# Altium schematic sheet agent-mother.SchDoc
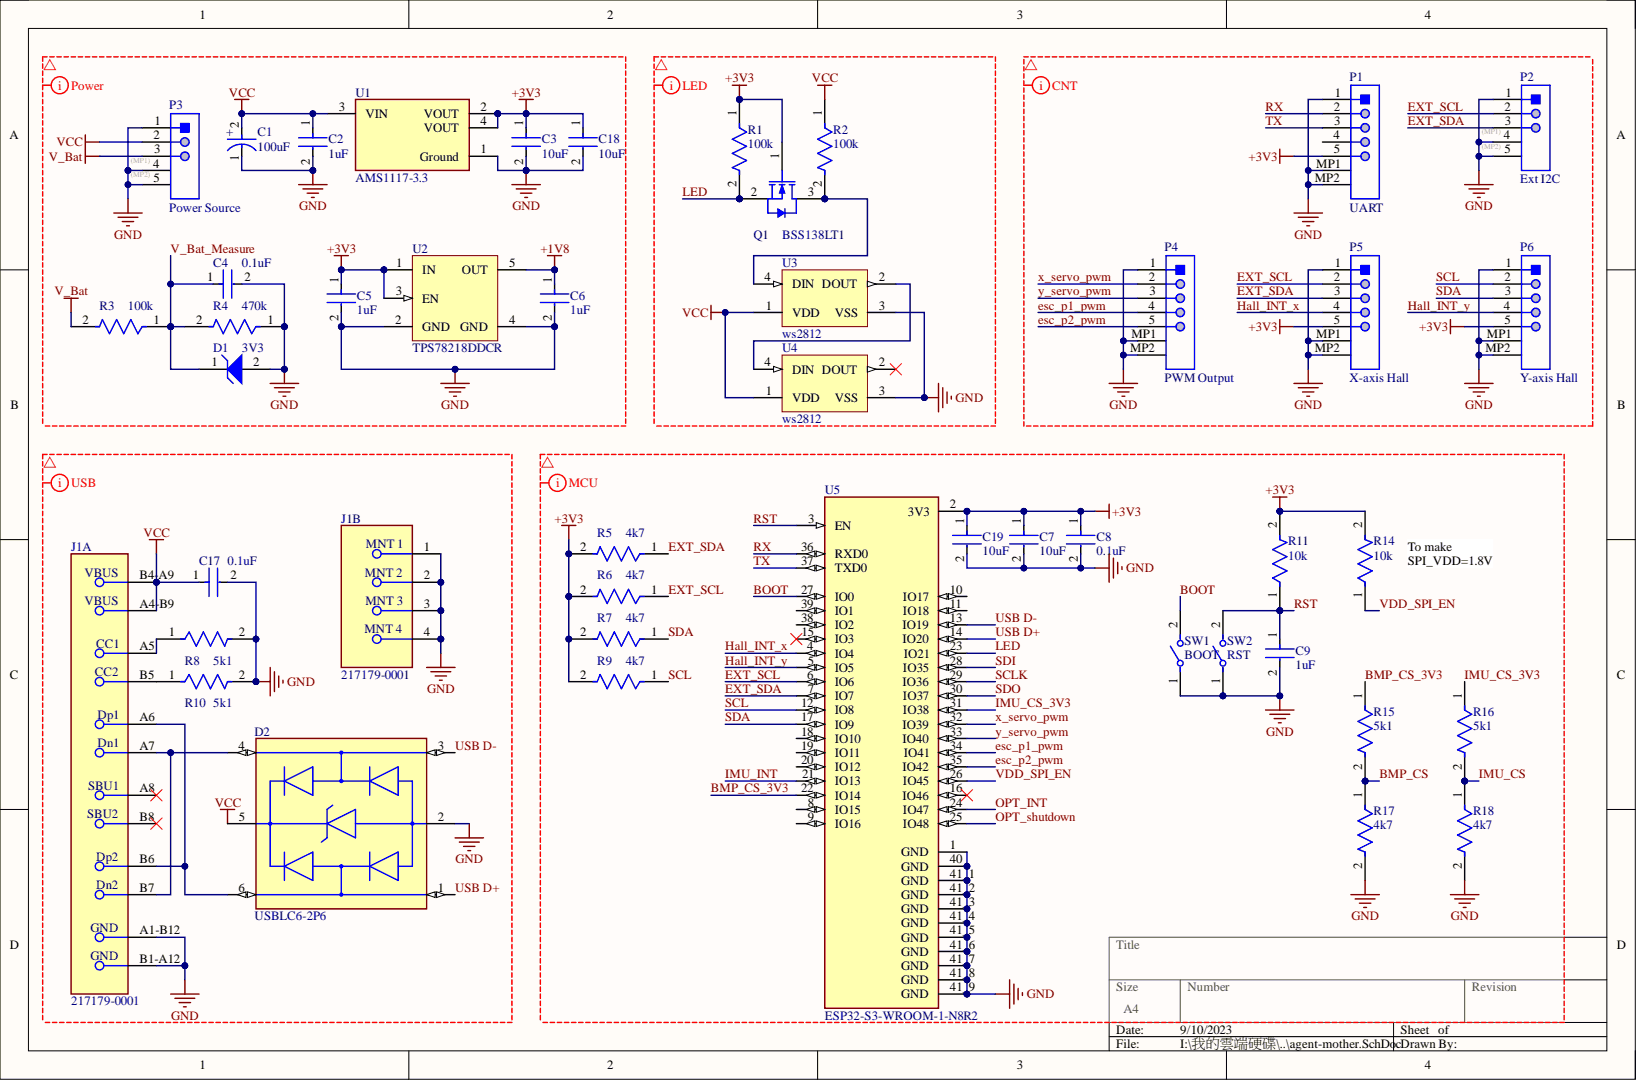
[diagram p0: agent-mother.SchDoc]

## Parts
C1  100uF  [FP-T495D107K016ATE125-MFG]
C2  1uF  [FP-C0402-BB-MFG]
C3  10uF  [FP-C0402-BB-MFG]
C4  0.1uF  [FP-C0402-BB-MFG]
C5  1uF  [FP-C0402-BB-MFG]
C6  1uF  [FP-C0402-BB-MFG]
C7  10uF  [FP-C0402-BB-MFG]
C8  0.1uF  [FP-C0402-BB-MFG]
C9  1uF  [FP-C0402-BB-MFG]
C17  0.1uF  [FP-C0402-BB-MFG]
C18  10uF  [FP-C0402-BB-MFG]
C19  10uF  [FP-C0402-BB-MFG]
D1  3V3  [ONSC-SOD-323-2-477-02_V]
D2  USBLC6-2P6  [SOT-23-6 USBLC6]
J1  217179-0001  [FP-217179-0001-MFG]  (parts A,B)
P1  UART  [MOLX-52361-0571-5_V]
P2  Ext I2C  [FP-53261-0371-MFG]
P3  Power Source  [FP-53261-0371-MFG]
P4  PWM Output  [MOLX-52361-0571-5_V]
P5  X-axis Hall  [MOLX-52361-0571-5_V]
P6  Y-axis Hall  [MOLX-52361-0571-5_V]
Q1  BSS138LT1  [ONSC-SOT-23-3-318-08_V]
R1  100k  [FP-CRCW0402-e3-IPC_A]
R2  100k  [FP-CRCW0402-e3-IPC_A]
R3  100k  [FP-CRCW0402-e3-IPC_A]
R4  470k  [FP-CRCW0402-e3-IPC_A]
R5  4k7  [FP-CRCW0402-e3-IPC_A]
R6  4k7  [FP-CRCW0402-e3-IPC_A]
R7  4k7  [FP-CRCW0402-e3-IPC_A]
R8  5k1  [FP-CRCW0402-e3-IPC_A]
R9  4k7  [FP-CRCW0402-e3-IPC_A]
R10  5k1  [FP-CRCW0402-e3-IPC_A]
R11  10k  [FP-CRCW0402-e3-IPC_A]
R14  10k  [FP-CRCW0402-e3-IPC_A]
R15  5k1  [FP-CRCW0402-e3-IPC_A]
R16  5k1  [FP-CRCW0402-e3-IPC_A]
R17  4k7  [FP-CRCW0402-e3-IPC_A]
R18  4k7  [FP-CRCW0402-e3-IPC_A]
SW1  BOOT  [FP-B3U-1000P-B-MFG]
SW2  RST  [FP-B3U-1000P-B-MFG]
U1  AMS1117-3.3  [FP-AMS1117-IPC_A]
U2  TPS78218DDCR  [SOT-23-OT5_M]
U3  ws2812  [ADA-RGB-1655_V]
U4  ws2812  [ADA-RGB-1655_V]
U5  ESP32-S3-WROOM-1-N8R2  [XCVR_ESP32S3WROOM1N8R8]

## Nets
+1V8: C6.1 U2.5(OUT)
+3V3: C3.1 C5.1 C7.1 C8.1 C18.1 C19.1 P1.5 P5.5 P6.5 Q1.1 R1.1 R5.2 R6.2 R7.2 R9.2 R11.2 R14.2 U1.2(VOUT) U1.4(VOUT) U2.1(IN) U2.3(EN) U5.2(3V3)
GND: C1.1 C2.2 C3.2 C4.2 C5.2 C6.2 C7.2 C8.2 C9.2 C17.2 C18.2 C19.2 D1.2 D2.2 J1.1 J1.2 J1.3 J1.4 J1.A1-B12 J1.B1-A12 P1.1 P1.MP1 P1.MP2 P2.1 P2.MP1 P2.MP2 P3.1 P3.MP1 P3.MP2 P4.1 P4.MP1 P4.MP2 P5.1 P5.MP1 P5.MP2 P6.1 P6.MP1 P6.MP2 R4.1 R8.2 R10.2 R17.2 R18.2 SW1.1 SW2.1 U1.1(Ground) U2.2(GND) U2.4(GND) U3.3(VSS) U4.3(VSS) U5.1(GND) U5.40(GND) U5.41_1(GND) U5.41_2(GND) U5.41_3(GND) U5.41_4(GND) U5.41_5(GND) U5.41_6(GND) U5.41_7(GND) U5.41_8(GND) U5.41_9(GND)
V_Bat: P3.3 R3.2
VCC: C1.2 C2.1 C17.1 D2.5 J1.A4-B9 J1.B4-A9 P3.2 R2.1 U1.3(VIN) U3.1(VDD) U4.1(VDD)
BMP_CS: R15.2 R17.1
BMP_CS_3V3: R15.1 U5.22(IO14)
BOOT: SW1.2 U5.27(IO0)
esc_p1_pwm: P4.4 U5.34(IO41)
esc_p2_pwm: P4.5 U5.35(IO42)
EXT_SCL: P2.2 P5.2 R6.1 U5.6(IO6)
EXT_SDA: P2.3 P5.3 R5.1 U5.7(IO7)
Hall_INT_x: P5.4 U5.4(IO4)
Hall_INT_y: P6.4 U5.5(IO5)
IMU_CS: R16.2 R18.1
IMU_CS_3V3: R16.1 U5.31(IO38)
IMU_INT: U5.21(IO13)
LED: Q1.2 R1.2 U5.23(IO21)
OPT_INT: U5.24(IO47)
OPT_shutdown: U5.25(IO48)
RST: C9.1 R11.1 SW2.2 U5.3(EN)
RX: P1.2 U5.36(RXD0)
SCL: P6.2 R9.1 U5.12(IO8)
SCLK: U5.29(IO36)
SDA: P6.3 R7.1 U5.17(IO9)
SDI: U5.28(IO35)
SDO: U5.30(IO37)
TX: P1.3 U5.37(TXD0)
USB D+: D2.1 U5.14(IO20)
USB D-: D2.3 U5.13(IO19)
V_Bat_Measure: C4.1 D1.1 R3.1 R4.2
VDD_SPI_EN: R14.1 U5.26(IO45)
x_servo_pwm: P4.2 U5.32(IO39)
y_servo_pwm: P4.3 U5.33(IO40)
NetD2_4: D2.4 J1.A7 J1.B7
NetD2_6: D2.6 J1.A6 J1.B6
NetJ1_A5: J1.A5 R8.1
NetJ1_B5: J1.B5 R10.1
NetQ1_3: Q1.3 R2.2 U3.4(DIN)
NetU3_2: U3.2(DOUT) U4.4(DIN)

Not connected: J1 A8 B8; P1 4; U4 2(DOUT); U5 8(IO15) 9(IO16) 10(IO17) 11(IO18) 15(IO3) 16(IO46) 18(IO10) 19(IO11) 20(IO12) 38(IO2) 39(IO1)

## Library data of the parts
C1 = CMP-2000-07207-2: CAP TANT 100UF 10% 16V 2917; Dielectric Material: Tantalum; Dissipation Factor: 8%; ESR (Equivalent Series Resistance): 125mR; Life (Hours): 2000Hour; Polarity: Polar; Capacitance: 100uF; Case Code (Imperial): 2917; Case Code (Metric): 7343; Case/Package: 2917; Component Kind: Standard; Construction: Flat; Depth: 4.3mm; Failure Rate: 0.001%; Features: General Purpose; Height: 2.8mm; Height - Seated (Max): 0.122inch; Length: 7.3mm; Library Name: Altium Content Vault; Max Operating Temperature: 125°C; Min Operating Temperature: -55°C; Mount: Surface Mount; Number of Pins: 2; Package Quantity: 1; Packaging: Tape and Reel; Radiation Hardening: No; REACH SVHC: No SVHC; RoHS Compliant: Yes; Series: T495; Termination: SMD/SMT; Tolerance: 10%; Voltage: 16V; Voltage Rating: 16V; Voltage Rating (DC): 16V; Weight: 0.023634oz; Width: 0.169inch
C2 C5 C6 C9 = CMP-2008-02108-2: CAP CER 1uF 16V X7R 0402; Manufacturer: Kemet; Manufacturer Part Number: C0402C104K4RACTU; Capacitance: 0.1uF; Material: Ceramic; Temperature Coefficient: 15%; Tolerance: 0.1; Value: 0.1uF; Automotive: No; Category: Cap; Component Kind: Standard; ComponentLink2Description: Datasheet; ComponentLink2URL: https://content.kemet.com/datasheets/KEM_C1002_X7R_SMD.pdf; Device Class L1: Passive Components; Device Class L2: Capacitors; Device Class L3: Ceramic Capacitors; Digikey Description: CAP CER 0.1UF 16V X7R 0402; Height: 0.55mm; Ipc Land Pattern Name: CAPC10050X50; Lead Free: Yes; Library Name: Altium Content Vault; Mouser Description: Cap Ceramic 0.1uF 16V X7R 10% SMD 0402 125?C Paper T/R - Tape and Reel (Alt: C0402C104K4RAC TU); Octopart: https://octopart.com/c0402c104k4ractu-kemet-94158; Package: C0402-BB; RoHS: TRUE; Temperature: -55°C to +125°C; Temperature Characteristic: X7R; Temperature Range High: +125°C; Temperature Range Low: -55°C; Voltage Rating: 16 V
C3 C7 C18 C19 = CMP-2008-02108-2: CAP CER 22uF 16V X7R 0402; Manufacturer: Kemet; Manufacturer Part Number: C0402C104K4RACTU; Capacitance: 0.1uF; Material: Ceramic; Temperature Coefficient: 15%; Tolerance: 0.1; Value: 0.1uF; Automotive: No; Category: Cap; Component Kind: Standard; ComponentLink2Description: Datasheet; ComponentLink2URL: https://content.kemet.com/datasheets/KEM_C1002_X7R_SMD.pdf; Device Class L1: Passive Components; Device Class L2: Capacitors; Device Class L3: Ceramic Capacitors; Digikey Description: CAP CER 0.1UF 16V X7R 0402; Height: 0.55mm; Ipc Land Pattern Name: CAPC10050X50; Lead Free: Yes; Library Name: Altium Content Vault; Mouser Description: Cap Ceramic 0.1uF 16V X7R 10% SMD 0402 125?C Paper T/R - Tape and Reel (Alt: C0402C104K4RAC TU); Octopart: https://octopart.com/c0402c104k4ractu-kemet-94158; Package: C0402-BB; RoHS: TRUE; Temperature: -55°C to +125°C; Temperature Characteristic: X7R; Temperature Range High: +125°C; Temperature Range Low: -55°C; Voltage Rating: 16 V
C4 C8 C17 = CMP-2008-02108-2: CAP CER 0.1uF 16V X7R 0402; Manufacturer: Kemet; Manufacturer Part Number: C0402C104K4RACTU; Material: Ceramic; Temperature Coefficient: 15%; Tolerance: 0.1; Automotive: No; Capacitance: 0.1uF; Category: Cap; Component Kind: Standard; ComponentLink2Description: Datasheet; ComponentLink2URL: https://content.kemet.com/datasheets/KEM_C1002_X7R_SMD.pdf; Device Class L1: Passive Components; Device Class L2: Capacitors; Device Class L3: Ceramic Capacitors; Digikey Description: CAP CER 0.1UF 16V X7R 0402; Height: 0.55mm; Ipc Land Pattern Name: CAPC10050X50; Lead Free: Yes; Library Name: Altium Content Vault; Mouser Description: Cap Ceramic 0.1uF 16V X7R 10% SMD 0402 125?C Paper T/R - Tape and Reel (Alt: C0402C104K4RAC TU); Octopart: https://octopart.com/c0402c104k4ractu-kemet-94158; Package: C0402-BB; RoHS: TRUE; Temperature: -55°C to +125°C; Temperature Characteristic: X7R; Temperature Range High: +125°C; Temperature Range Low: -55°C; Value: 0.1uF; Voltage Rating: 16 V
D1 = CMP-1060-00672-1: Zener Voltage Regulator, 300 mW, 2-Pin SOD-323, Pb-Free, Tape and Reel; Manufacturer: On Semiconductor; PartNumber: MM3Z3V3T1G; P Max (W): 0.3; VZ Typ (V): 3.3; Component Kind: Standard; ComponentLink1Description: Manufacturer URL; ComponentLink1URL: http://www.onsemi.com/; ComponentLink2Description: Datasheet; ComponentLink2URL: http://www.onsemi.cn/pub_link/Collateral/MM3Z2V4T1-D.PDF; ComponentLink3Description: Package Specification; ComponentLink3URL: http://www.onsemi.com/pub_link/Collateral/477-02.PDF.1; DatasheetVersion: Rev. 9; Library Name: Altium Content Vault; Mounting Technology: Surface Mount; PackageDescription: 2-Pin SOD, Body 1.7 x 1.25 mm; PackageReference: SOD-323-2-477-02; PackageVersion: Rev. H, 03/2007; Packing: Tape and Reel; RoHS: Pb-Free
D2 = USBLC6-2P6: TVS DIODE 5.25V 17V SOT666; Breakdown Voltage: 6V; Capacitance: 3.5pF; Direction: Unidirectional; Element Configuration: Dual; ESD Protection: Yes; Leakage Current: 150nA; Number of Bidirectional Channels: 2; Number of Elements: 1; Operating Supply Voltage: 5V; Peak Pulse Current: 5A; Test Current: 1mA; Case/Package: SOT-666; Clamping Voltage: 17V; Component Kind: Standard; Contact Plating: Tin; Depth: 1.3mm; Height: 0.6mm; Lead Free: Lead Free; Length: 1.7mm; Max Breakdown Voltage: 6V; Max Operating Temperature: 125°C; Max Reverse Leakage Current: 150nA; Min Breakdown Voltage: 6V; Min Operating Temperature: -40°C; Mount: Surface Mount; Number of Channels: 2; Number of Pins: 6; Package Quantity: 1; Packaging: Tape and Reel; Polarity: Unidirectional; Power Line Protection: Yes; Radiation Hardening: No; REACH SVHC: No SVHC; Reverse Breakdown Voltage: 6V; Reverse Standoff Voltage: 5V; Termination: SMD/SMT; Width: 1.3mm; Working Voltage: 5V; Library Name: TVMD.SchLib
J1 = CMP-04767-000028-1: CONN RCP USB2.0 TYP C 24P SMD RA; Component Kind: Standard; Library Name: Altium Content Vault
P1 P4-P6 = CMP-2000-05249-1: Male Header, Pitch 1.25 mm, 1 x 5 Position, Height 3.4 mm, RoHS, Tape and Reel; Brand: Molex; Current Rating: 1A; Mounting Angle: Right; Number of Positions: 5; Pitch: 1.25mm; Voltage Rating: 125V; Component Kind: Standard; Contact Material: PhosphorBronze; Contact Plating: Tin; Datasheet URL: http://datasheet.octopart.com/532610571-Molex-datasheet-8343080.pdf; Datasheet Version: Rev. D, 04/2004; Factory Pack Quantity: 1000; Housing Material: Thermoplastic; Library Name: Altium Content Vault; Manufacturer: Molex; Manufacturer URL: http://www.molex.com/; Mounting Style: SMD/SMT; Number of Positions / Contacts: 5; Number of Rows: 1; Packaging: Reel; Product Category: Headers&WireHousings; Product Type: Headers; RoHS: Details; Series: 53261; Termination Style: SMD; Tradename: PicoBlade; Type: Shrouded
P2 P3 = CMP-04776-000071-1: CONN HEADER SMD R/A 3POS 1.25MM; Manufacturer: Molex; Manufacturer Part Number: 53261-0371; Conn Gender: Male; Conn Orientation: Right Angle; Current Rating: 1A; Number Of Contacts: 3; Voltage Rating: 125V; Ambient Temperature Range High: +105°C; Ambient Temperature Range Low: -40°C; Automotive: No; Category: Conn; Component Kind: Standard; ComponentLink2Description: Datasheet; ComponentLink2URL: https://www.molex.com/webdocs/datasheets/pdf/en-us/0532610371_PCB_HEADERS.pdf; Contact Material: Tin,Nickel; Device Class L1: Connectors; Device Class L2: Headers and Wire Housings; Device Class L3: unset; Digikey Description: CONN HEADER SMD R/A 3POS 1.25MM; Footprint Url: https://www.molex.com/pdm_docs/sd/532610371_sd.pdf; Height: 3.6mm; Lead Free: Yes; Library Name: Components - 19.08.23 - 20.17.SchLib; Mouser Description: 1.25mm (.049") Pitch PicoBlade Header, Surface Mount, Right Angle, Lead-free, 3 Circuits; Number Of Rows: 1; Octopart: https://octopart.com/53261-0371-molex-655314; Package: 0; Pitch: 1.25mm; RoHS: TRUE; Temperature: -40°C to +105°C; Temperature Range High: +105°C; Temperature Range Low: -40°C
Q1 = CMP-1058-00761-1: Power MOSFET, BSS138LT1, 200 mA, 50 V, N-Channel, 3-Pin SOT-23, Tape and Reel; Manufacturer: On Semiconductor; Channel Polarity: N Channel; Ciss Typ (pF): 40; Configuration: Single; Coss Typ (pF): 12; Crss Typ (pF): 3.5; ID Max (A): 0.2; PD Max (W): 0.225; V(BR)DSS Min (V): 50; VGS Max (V): 20; VGS(th) Max (V): 1.5; Component Kind: Standard; ComponentLink1Description: Manufacturer URL; ComponentLink1URL: http://www.onsemi.com/; ComponentLink2Description: Datasheet; ComponentLink2URL: http://www.onsemi.com/pub_link/Collateral/BSS138LT1-D.PDF; ComponentLink3Description: Package Specification; ComponentLink3URL: http://www.onsemi.cn/pub_link/Collateral/318-08.PDF; DatasheetVersion: Rev. 8; Library Name: Altium Content Vault; Mounting Technology: Surface Mount; Package Type: SOT-23-3; PackageDescription: 3-Pin Small Outline Transistor, Body 2.92 x 1.3 mm, Pitch 0.95 mm; PackageReference: SOT-23-3-318-08; PackageVersion: Rev. AP, 11/2009; Packing: Tape and Reel; PartNumber: BSS138LT1
R1-R3 = CMP-2002-08122-2: RES Thick Film, 100kΩ, 0.063W, 0402; Max Power Dissipation: 63mW; Resistance: 5k1; Temperature Coefficient: 100ppm/°C; Tolerance: 5%; Voltage Rating: 50V; Case Code (Imperial): 0402; Case Code (Metric): 1005; Case/Package: 0402; Component Kind: Standard; Composition: Thick Film; Contact Plating: Tin; Depth: 0.5mm; Features: Automotive AEC-Q200; Height: 0.35mm; Lead Free: Lead Free; Length: 1mm; Library Name: Altium Content Vault; Max Operating Temperature: 155°C; Military Standard: Not; Min Operating Temperature: -55°C; Mount: Surface Mount; Number of Terminations: 2; Package Quantity: 1; Packaging: Tape and Reel; Power Rating: 63mW; Radiation Hardening: No; Termination: SMD/SMT; Voltage Rating (DC): 50V; Weight: 2.3E-05oz; Width: 0.5mm
R4 = CMP-2002-08122-2: RES Thick Film, 470kΩ, 0.063W, 0402; Max Power Dissipation: 63mW; Resistance: 5k1; Temperature Coefficient: 100ppm/°C; Tolerance: 5%; Voltage Rating: 50V; Case Code (Imperial): 0402; Case Code (Metric): 1005; Case/Package: 0402; Component Kind: Standard; Composition: Thick Film; Contact Plating: Tin; Depth: 0.5mm; Features: Automotive AEC-Q200; Height: 0.35mm; Lead Free: Lead Free; Length: 1mm; Library Name: Altium Content Vault; Max Operating Temperature: 155°C; Military Standard: Not; Min Operating Temperature: -55°C; Mount: Surface Mount; Number of Terminations: 2; Package Quantity: 1; Packaging: Tape and Reel; Power Rating: 63mW; Radiation Hardening: No; Termination: SMD/SMT; Voltage Rating (DC): 50V; Weight: 2.3E-05oz; Width: 0.5mm
R5-R7 R9 R17 R18 = CMP-2002-08122-2: RES Thick Film, 4k7Ω, 0.063W, 0402; Max Power Dissipation: 63mW; Resistance: 5k1; Temperature Coefficient: 100ppm/°C; Tolerance: 5%; Voltage Rating: 50V; Case Code (Imperial): 0402; Case Code (Metric): 1005; Case/Package: 0402; Component Kind: Standard; Composition: Thick Film; Contact Plating: Tin; Depth: 0.5mm; Features: Automotive AEC-Q200; Height: 0.35mm; Lead Free: Lead Free; Length: 1mm; Library Name: Altium Content Vault; Max Operating Temperature: 155°C; Military Standard: Not; Min Operating Temperature: -55°C; Mount: Surface Mount; Number of Terminations: 2; Package Quantity: 1; Packaging: Tape and Reel; Power Rating: 63mW; Radiation Hardening: No; Termination: SMD/SMT; Voltage Rating (DC): 50V; Weight: 2.3E-05oz; Width: 0.5mm
R8 R10 = CMP-2002-08122-2: RES Thick Film, 5k1Ω, 0.063W, 0402; Max Power Dissipation: 63mW; Temperature Coefficient: 100ppm/°C; Tolerance: 5%; Voltage Rating: 50V; Case Code (Imperial): 0402; Case Code (Metric): 1005; Case/Package: 0402; Component Kind: Standard; Composition: Thick Film; Contact Plating: Tin; Depth: 0.5mm; Features: Automotive AEC-Q200; Height: 0.35mm; Lead Free: Lead Free; Length: 1mm; Library Name: Altium Content Vault; Max Operating Temperature: 155°C; Military Standard: Not; Min Operating Temperature: -55°C; Mount: Surface Mount; Number of Terminations: 2; Package Quantity: 1; Packaging: Tape and Reel; Power Rating: 63mW; Radiation Hardening: No; Resistance: 5k1; Termination: SMD/SMT; Voltage Rating (DC): 50V; Weight: 2.3E-05oz; Width: 0.5mm
R11 R14 = CMP-2002-08122-2: RES Thick Film, 10kΩ, 0.063W, 0402; Max Power Dissipation: 63mW; Resistance: 5k1; Temperature Coefficient: 100ppm/°C; Tolerance: 5%; Voltage Rating: 50V; Case Code (Imperial): 0402; Case Code (Metric): 1005; Case/Package: 0402; Component Kind: Standard; Composition: Thick Film; Contact Plating: Tin; Depth: 0.5mm; Features: Automotive AEC-Q200; Height: 0.35mm; Lead Free: Lead Free; Length: 1mm; Library Name: Altium Content Vault; Max Operating Temperature: 155°C; Military Standard: Not; Min Operating Temperature: -55°C; Mount: Surface Mount; Number of Terminations: 2; Package Quantity: 1; Packaging: Tape and Reel; Power Rating: 63mW; Radiation Hardening: No; Termination: SMD/SMT; Voltage Rating (DC): 50V; Weight: 2.3E-05oz; Width: 0.5mm
R15 R16 = CMP-2002-08122-2: RES Thick Film, 4k7Ω, 0.063W, 0402; Max Power Dissipation: 63mW; Temperature Coefficient: 100ppm/°C; Tolerance: 5%; Voltage Rating: 50V; Case Code (Imperial): 0402; Case Code (Metric): 1005; Case/Package: 0402; Component Kind: Standard; Composition: Thick Film; Contact Plating: Tin; Depth: 0.5mm; Features: Automotive AEC-Q200; Height: 0.35mm; Lead Free: Lead Free; Length: 1mm; Library Name: Altium Content Vault; Max Operating Temperature: 155°C; Military Standard: Not; Min Operating Temperature: -55°C; Mount: Surface Mount; Number of Terminations: 2; Package Quantity: 1; Packaging: Tape and Reel; Power Rating: 63mW; Radiation Hardening: No; Resistance: 5k1; Termination: SMD/SMT; Voltage Rating (DC): 50V; Weight: 2.3E-05oz; Width: 0.5mm
SW1 SW2 = CMP-08834-000005-1: SWITCH TACTILE SPST-NO 0.05A 12V; Manufacturer: Omron; Manufacturer Part Number: B3U-1000P-B; Contact Current Rating: 50mA; Contact Resistance: 100mΩ; Electromechanical Life: 200000Cycles; Operating Force: 1.5N; Ambient Temperature Range High: +70°C; Ambient Temperature Range Low: -25°C; Automotive: No; Category: Switch; Component Kind: Standard; ComponentLink2Description: Datasheet; ComponentLink2URL: https://omronfs.omron.com/en_US/ecb/products/pdf/en-b3u.pdf; Device Class L1: Electromechanical; Device Class L2: Switches; Device Class L3: Tactile Switches; Digikey Description: SWITCH TACTILE SPST-NO 0.05A 12V; Height: 1.75mm; Lead Free: Yes; Library Name: Altium Content Vault; Mount: Surface Mount; Mouser Description: Tactile Switches Top Actuated with boss w/o ground; Octopart: https://octopart.com/b3u-1000p-b-omron-1057356; Package: 0; RoHS: TRUE; Temperature: -25°C to +70°C; Temperature Range High: +70°C; Temperature Range Low: -25°C; Throw Configuration: SPST-NO; Voltage Rating Dc: 12V
U1 = CMP-209535-000001-1: LDO Voltage Regulators 1A, 3.3V; Manufacturer: Advanced Monolithic Systems; Dropout Voltage: 1.1V; Max Junction Temp: +125°C; Max Power Dissipation: 1.2W; Max Supply Voltage: 15V; Nominal Supply Current: 5mA; Number Of Outputs: 1; Output Type: Fixed; Standoff Height: 0.64mm; Automotive: No; Category: IC; Component Kind: Standard; ComponentLink2Description: Datasheet; ComponentLink2URL: http://www.advanced-monolithic.com/pdf/ds1117.pdf; Device Class L1: Integrated Circuits (ICs); Device Class L2: Power Management ICs; Device Class L3: Voltage Regulators - Linear; Height: 1.8mm; Ipc Land Pattern Name: SOT229P700X180-4; Lead Free: Yes; Library Name: Altium Content Vault; Manufacturer Part Number: AMS1117-3.3; Octopart: https://octopart.com/ams1117-3.3-advanced+monolithic+systems-25899300; Output Current: 1A; Output Voltage: 3.3V; Package: AMS1117; RoHS: TRUE; Temperature: -40°C to +125°C; Temperature Range High: +125°C; Temperature Range Low: -40°C
U2 = TI-TPS782XXDRV6-1600X1000TP: Single Output LDO, 150 mA, Fixed 1.8 V Output, 2.2 to 5.5 V Input, with 0.5 uA Quiescent Current, 6-pin SON (DRV), -40 to 125 degC, Green (RoHS & no Sb/Br); Manufacturer: Texas Instruments; PartNumber: TPS78218DRVR; Accuracy(%): 3; Fixed Output Options(V): 1.8; Iout(Max)(A): 0.15; Iq(Typ)(mA): 0.0005; Rating: Catalog; SubFamily: Single Channel LDO; Vdo(Typ)(mV): 130; Vin(Max)(V): 5.5; Vin(Min)(V): 2.2; Component Kind: Standard; ComponentLink1Description: Manufacturer URL; ComponentLink1URL: http://www.ti.com/; ComponentLink2Description: Datasheet; ComponentLink2URL: http://www.ti.com/general/docs/lit/getliterature.tsp?genericPartNumber=TPS78218&fileType=pdf; ComponentLink3Description: Package Specification; ComponentLink3URL: http://www.ti.com/litv/pdf/mpds216d; DatasheetVersion: SBVS115B; Eco-Plan: Green (RoHS & no Sb/Br); Mounting Technology: Surface Mount; PackageDescription: 6-Pin Plastic Small Outline No-Lead, Body 2 x 2 mm, Pitch 0.65 mm; PackageReference: DRV0006A; PackageVersion: 4206925/E; Vout Adj(Max)(V): 1.8; Vout Adj(Min)(V): 1.8; Library Name: TVMD.SchLib
U3 U4 = CMP-2000-05026-1: Intelligent Control LED Integrated Light Source, 3.5 to 5.3 V, -25 to 80 degC, 4-Pin SMD, RoHS, Tape and Reel; Manufacturer: Adafruit-Industries-LLC; Configuration: Discrete; Number of LEDs: 10; Utilized LED: WS2812(5050); Category: Optoelectronics; Color: Red,Green,Blue(RGB); Component Kind: Standard; Controller Type: -; Datasheet URL: http://datasheet.octopart.com/ADA1655-Adafruit-Industries-datasheet-32028139.pdf; Datasheet Version: May-13; Family: Addressable,Specialty; Interface: -; Library Name: Altium Content Vault; Manufacturer URL: https://www.adafruit.com/; Mounting Type: SurfaceMount; Package Description: 4-Pin Surface Mount Device, Body 5 x 5 mm; Package Reference: 1655; Series: Neopixel; Size / Dimension: 5.00mmLx5.00mmW
U5 = ESP32-S3-WROOM-1-N8R8: Bluetooth, WiFi 802.11b/g/n, Bluetooth v5.0 Transceiver Module 2.4GHz PCB Trace Surface Mount; MF: Espressif Systems; Availability: In Stock; Check_prices: https://www.snapeda.com/parts/ESP32-S3-WROOM-1-N8R8/Espressif+Systems/view-part/?ref=eda; Component Kind: Standard; Library Name: ESP32-S3-WROOM-1-N8R8.IntLib; MP: ESP32-S3-WROOM-1-N8R8; Package: None; Price: None; Purchase-URL: https://pricing.snapeda.com/search?q=ESP32-S3-WROOM-1-N8R8&ref=eda; SnapEDA_Link: https://www.snapeda.com/parts/ESP32-S3-WROOM-1-N8R8/Espressif+Systems/view-part/?ref=snap
